# Altium schematic sheet Sensors.SchDoc(Sensors)
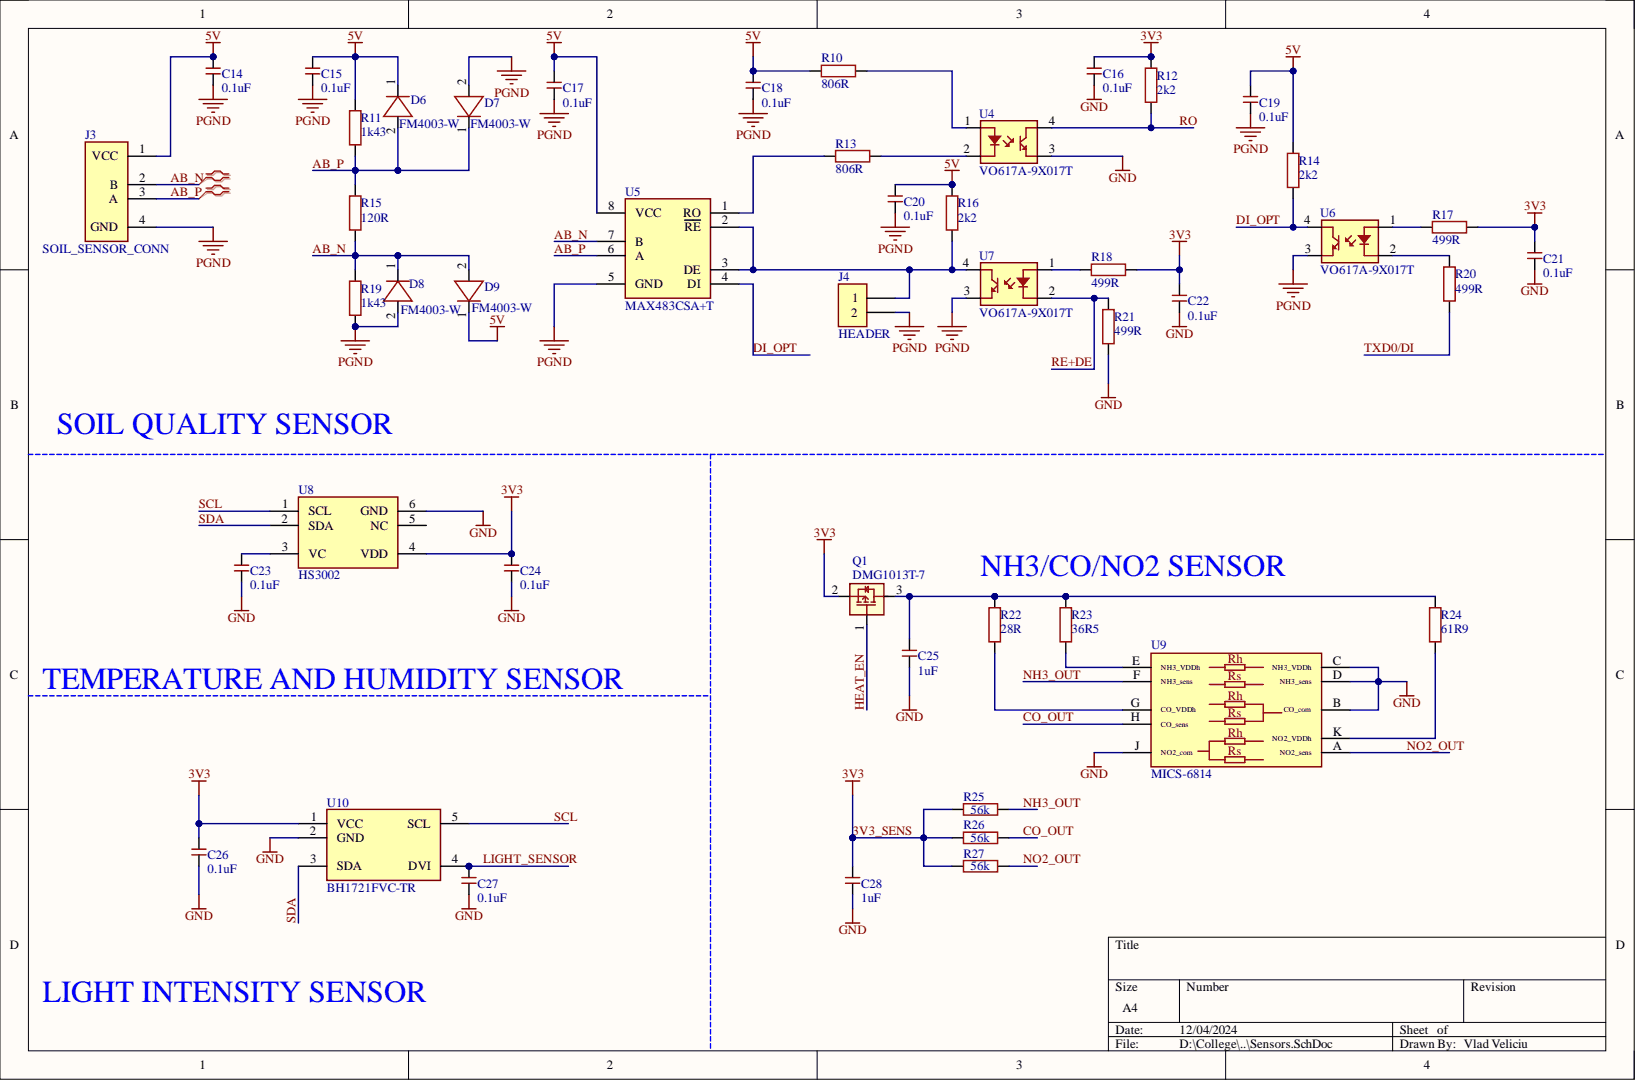
[diagram p0: Sensors.SchDoc]

## Parts
C14  0.1uF  [C0603]
C15  0.1uF  [C0603]
C16  0.1uF  [C0603]
C17  0.1uF  [C0603]
C18  0.1uF  [C0603]
C19  0.1uF  [C0603]
C20  0.1uF  [C0603]
C21  0.1uF  [C0603]
C22  0.1uF  [C0603]
C23  0.1uF  [C0603]
C24  0.1uF  [C0603]
C25  1uF  [C0805]
C26  0.1uF  [C0603]
C27  0.1uF  [C0603]
C28  1uF  [C0805]
D6  FM4003-W  [FM4003]
D7  FM4003-W  [FM4003]
D8  FM4003-W  [FM4003]
D9  FM4003-W  [FM4003]
J3  SOIL_SENSOR_CONN  [9993920000]
J4  HEADER  [TMM-104-YY-XX-D-SM-2pins]
Q1  DMG1013T-7  [SOT523]
R10  806R  [R0805]
R11  1k43  [R1206]
R12  2k2  [R0805]
R13  806R  [R0805]
R14  2k2  [R0805]
R15  120R  [R0805]
R16  2k2  [R0805]
R17  499R  [R0805]
R18  499R  [R0805]
R19  1k43  [R1206]
R20  499R  [R0805]
R21  499R  [R0805]
R22  28R  [R0603]
R23  36R5  [R0805]
R24  61R9  [R0805]
R25  56k  [R0805]
R26  56k  [R0805]
R27  56k  [R0805]
U4  VO617A-9X017T  [VO617A-9X017T]
U5  MAX483CSA+T  [SOIC-8]
U6  VO617A-9X017T  [VO617A-9X017T]
U7  VO617A-9X017T  [VO617A-9X017T]
U8  HS3002  [6-LGA]
U9  MICS-6814  [MICS-6814]
U10  BH1721FVC-TR  [WSOF5]

## Nets
5V: C14.2 C15.2 C17.2 C18.2 C19.2 C20.2 D6.1 D9.1 J3.1(VCC) R10.1 R11.2 R14.2 R16.2 U5.8(VCC)
GND: C16.1 C21.1 C22.1 C23.1 C24.1 C25.1 C26.1 C27.1 C28.1 R21.1 U4.3 U8.6(GND) U9.B(CO_com) U9.C(NH3_VDDh) U9.D(NH3_sens) U9.J(NO2_com) U10.2(GND)
PGND: C14.1 C15.1 C17.1 C18.1 C19.1 C20.1 D7.2 D8.2 J3.4(GND) J4.2 R19.1 U5.5(GND) U6.3 U7.3
3V3_SENS: C16.2 C21.2 C22.2 C24.2 C26.2 C28.2 Q1.2 R12.2 R17.2 R18.2 R25.1 R26.1 R27.1 U8.4(VDD) U10.1(VCC)
AB_N: D8.1 D9.2 J3.2(B) R15.1 R19.2 U5.7(B)
AB_P: D6.2 D7.1 J3.3(A) R11.1 R15.2 U5.6(A)
CO_OUT: R26.2 U9.H(CO_sens)
DI_OPT: R14.1 U5.4(DI) U6.4
HEAT_EN: Q1.1
LIGHT_SENSOR: C27.2 U10.4(DVI)
NH3_OUT: R25.2 U9.F(NH3_sens)
NO2_OUT: R27.2 U9.A(NO2_sens)
RE+DE: R21.2 U7.2
RO: R12.1 U4.4
SCL: U8.1(SCL) U10.5(SCL)
SDA: U8.2(SDA) U10.3(SDA)
TXD0/DI: R20.1
NetC23_2: C23.2 U8.3(VC)
NetC25_2: C25.2 Q1.3 R22.2 R23.2 R24.2
NetJ4_1: J4.1 R16.1 U5.2(RE) U5.3(DE) U7.4
NetR10_2: R10.2 U4.1
NetR13_1: R13.1 U5.1(RO)
NetR13_2: R13.2 U4.2
NetR17_1: R17.1 U6.1
NetR18_1: R18.1 U7.1
NetR20_2: R20.2 U6.2
NetR22_1: R22.1 U9.G(CO_VDDh)
NetR23_1: R23.1 U9.E(NH3_VDDh)
NetR24_1: R24.1 U9.K(NO2_VDDh)

Not connected: U8 5(NC)

## Library data of the parts
C14-C24 C26 C27 = C0603C104K8RAC: Ceramic Capacitor, Multilayer, Ceramic, 10V, 10% +Tol, 10% -Tol, X7R, 15% TC, 0.1uF, Surface Mount, 0603; Manufacturer: KEMET; Multilayer: Yes; Value: 100nF; Capacitor Type: Ceramic; Case/Package: 0603; Category: Ceramic Capacitors; Component Kind: Standard; ComponentLink1Description: https://datasheet.ciiva.com:443/pdfs/VipMasterIC/IC/KEME/KEME-S-A0008991654/KEME-S-A0008991654-1.pdf?src-supplier=IHS+Markit; ComponentLink1URL: https://datasheet.ciiva.com:443/pdfs/VipMasterIC/IC/KEME/KEME-S-A0008991654/KEME-S-A0008991654-1.pdf?src-supplier=IHS+Markit; ComponentLink2Description: http://www.mouser.com/ds/2/212/KEM_C1002_X7R_SMD-1102033.pdf?src-supplier=Mouser; ComponentLink2URL: http://www.mouser.com/ds/2/212/KEM_C1002_X7R_SMD-1102033.pdf?src-supplier=Mouser; Dielectric Material: Ceramic; Height: 0.8mm; JESD-609 Code: e3; Length: 1.6mm; Library Name: Schlib1.SchLib; Manufacturer Part Number: C0603C104K8RAC; Max Operating Temperature: 125°C; Min Operating Temperature: -55°C; Mounting Technology: Surface Mount; Package Shape: Rectangular; Package Style: SMTMeter; Packaging: Tape and Reel; Pins: 2; Pricing: 1=0.1, 10=0.061, 50=0.061, 100=0.031, 1000=0.022, 10000=0.015 (USD); Rated DC Voltage (URdc): 10V; Reach SVHC Compliant: Yes; RoHS: Yes; RoHS Compliant: Yes; Stock: 209299; Supplier: Mouser; Supplier Part Number: 80-C0603C104K8RAC; Temperature Characteristics Code: X7R; Terminal Finish: Nickel; Termination: Wraparound; Tolerance  (fill in as +-): 10%; Tolerance (fill in as +-): 10%; Width: 0.8mm
C25 C28 = C0805C105J4RACTU: Ceramic Capacitor, Multilayer, Ceramic, 16V, 5% +Tol, 5% -Tol, X7R, 15% TC, 1uF, Surface Mount, 0805; Manufacturer: KEMET; Multilayer: Yes; Capacitor Type: Ceramic; Case/Package: 0805; Category: Ceramic Capacitors; Component Kind: Standard; ComponentLink1Description: https://datasheet.ciiva.com:443/pdfs/VipMasterIC/IC/KEME/KEME-S-A0008991654/KEME-S-A0008991654-1.pdf?src-supplier=IHS+Markit; ComponentLink1URL: https://datasheet.ciiva.com:443/pdfs/VipMasterIC/IC/KEME/KEME-S-A0008991654/KEME-S-A0008991654-1.pdf?src-supplier=IHS+Markit; ComponentLink2Description: http://www.farnell.com/datasheets/2315440.pdf?src-supplier=Farnell; ComponentLink2URL: http://www.farnell.com/datasheets/2315440.pdf?src-supplier=Farnell; ComponentLink3Description: http://components.arrow.com/part/dataview/30748390S8976888N7429?src-supplier=Arrow+Electronics; ComponentLink3URL: http://components.arrow.com/part/dataview/30748390S8976888N7429?src-supplier=Arrow+Electronics; ComponentLink4Description: http://www.farnell.com/datasheets/2746093.pdf?src-supplier=Farnell; ComponentLink4URL: http://www.farnell.com/datasheets/2746093.pdf?src-supplier=Farnell; ComponentLink5Description: http://www.mouser.com/ds/2/212/KEM_C1002_X7R_SMD-1102033.pdf?src-supplier=Mouser; ComponentLink5URL: http://www.mouser.com/ds/2/212/KEM_C1002_X7R_SMD-1102033.pdf?src-supplier=Mouser; ComponentLink6Description: http://datasheet.octopart.com/C0805C105J4RACTU-KEMET-datasheet-101576154.pdf?src-supplier=Rs; ComponentLink6URL: http://datasheet.octopart.com/C0805C105J4RACTU-KEMET-datasheet-101576154.pdf?src-supplier=Rs; ComponentLink7Description: http://capacitoredge.kemet.com/capedge2/DataSheet?pn=C0805C105J4RACTU&src-supplier=Avnet; ComponentLink7URL: http://capacitoredge.kemet.com/capedge2/DataSheet?pn=C0805C105J4RACTU&src-supplier=Avnet; ComponentLink8Description: https://datasheet.octopart.com/C0805C105J4RACTU-Kemet-datasheet-21527579.pdf?src-supplier=Newark; ComponentLink8URL: https://datasheet.octopart.com/C0805C105J4RACTU-Kemet-datasheet-21527579.pdf?src-supplier=Newark; ComponentLink9Description: http://www.farnell.com/datasheets/2746093.pdf?src-supplier=Newark; ComponentLink9URL: http://www.farnell.com/datasheets/2746093.pdf?src-supplier=Newark; ComponentLink10Description: http://datasheet.octopart.com/C0805C105J4RACTU-KEMET-datasheet-101576154.pdf?src-supplier=Digikey; ComponentLink10URL: http://datasheet.octopart.com/C0805C105J4RACTU-KEMET-datasheet-101576154.pdf?src-supplier=Digikey; ComponentLink11Description: https://api.kemet.com/component-edge/download/datasheet/C0805C105J4RACTU.pdf?src-supplier=Digikey; ComponentLink11URL: https://api.kemet.com/component-edge/download/datasheet/C0805C105J4RACTU.pdf?src-supplier=Digikey; ComponentLink12Description: http://datasheet.octopart.com/C0805C105J4RACTU-KEMET-datasheet-101576154.pdf?src-supplier=Onlinecomponents.com; ComponentLink12URL: http://datasheet.octopart.com/C0805C105J4RACTU-KEMET-datasheet-101576154.pdf?src-supplier=Onlinecomponents.com; ComponentLink13Description: http://www.farnell.com/datasheets/2746093.pdf?src-supplier=Element14; ComponentLink13URL: http://www.farnell.com/datasheets/2746093.pdf?src-supplier=Element14; ComponentLink14Description: https://datasheet.octopart.com/C0805C105J4RACTU-Kemet-datasheet-65850099.pdf?src-supplier=Element14; ComponentLink14URL: https://datasheet.octopart.com/C0805C105J4RACTU-Kemet-datasheet-65850099.pdf?src-supplier=Element14; ComponentLink15Description: http://www.farnell.com/datasheets/1828800.pdf?src-supplier=Element14; ComponentLink15URL: http://www.farnell.com/datasheets/1828800.pdf?src-supplier=Element14; ComponentLink16Description: https://datasheet.octopart.com/C0805C105J4RACTU-KEMET-datasheet-37550250.pdf?src-supplier=Electro+Sonic; ComponentLink16URL: https://datasheet.octopart.com/C0805C105J4RACTU-KEMET-datasheet-37550250.pdf?src-supplier=Electro+Sonic; Dielectric Material: Ceramic; Height: 0.9mm; JESD-609 Code: e3; Length: 2mm; Library Name: Schlib1.SchLib; Manufacturer Part Number: C0805C105J4RACTU; Max Operating Temperature: 125°C; Min Operating Temperature: -55°C; Mounting Technology: Surface Mount; Package Shape: Rectangular; Package Style: SMTMeter; Packaging: Tape and Reel; Pins: 2; Pricing: 1=0.32, 10=0.209, 50=0.1542, 100=0.1255, 500=0.09908, 1000=0.08367 (USD); Rated DC Voltage (URdc): 16V; Reach SVHC Compliant: Yes; RoHS: Yes; RoHS Compliant: Yes; Stock: 23162; Supplier: Digikey; Supplier Part Number: 399-C0805C105J4RAC7800CT-ND; Temperature Characteristics Code: X7R; Terminal Finish: Nickel; Termination: Wraparound; Tolerance  (fill in as +-): 5%; Tolerance (fill in as +-): 5%; Value: 1uF; Width: 1.25mm
D6-D9 = FM4003-W: Rectifier Diode, 1 Phase, 1 Element, 1A, 200V V(RRM), Silicon, DO-214AC; Manufacturer: Rectron; Forward Voltage: 1.1V; Non-rep Pk Forward Current-Max: 30A; Number of Elements: 1; Package Body Material: Plastic; Qualification Status: Not Qualified; Time @ Peak Reflow Temperature-Max (s): 10s; Category: Rectifier Diodes; Component Kind: Standard; ComponentLink1Description: https://datasheet.ciiva.com:443/pdfs/VipMasterIC/IC/RECT/RECT-S-A0006483850/RECT-S-A0006483850-1.pdf?src-supplier=IHS+Markit; ComponentLink1URL: https://datasheet.ciiva.com:443/pdfs/VipMasterIC/IC/RECT/RECT-S-A0006483850/RECT-S-A0006483850-1.pdf?src-supplier=IHS+Markit; ComponentLink2Description: http://www.mouser.com/ds/2/345/rectron_fm4001w-fm4007w-347498.pdf?src-supplier=Mouser; ComponentLink2URL: http://www.mouser.com/ds/2/345/rectron_fm4001w-fm4007w-347498.pdf?src-supplier=Mouser; Diode Element Material: SILICON; Diode Type: RECTIFIER; JEDEC-95 Code: DO-214AC; JESD-30 Code: R-PDSO-C2; JESD-609 Code: e3; Library Name: Schlib1.SchLib; Manufacturer Part Number: FM4003-W; Max Operating Temperature: 150°C; Max Output current: 1A; Package Shape: Rectangular; Package Style: SMALL OUTLINEMeter; Peak Reflow Temperature (Cel): 260°C; Pins: 2; Pricing: 1=0.24, 10=0.16, 50=0.16, 100=0.089, 1000=0.052, 10000=0.034 (USD); Reach SVHC Compliant: No; Rep Pk Reverse Voltage-Max: 200V; RoHS: Yes; RoHS Compliant: Yes; Stock: 13549; Supplier: Mouser; Supplier Part Number: 583-FM4003-W; Surface Mount: Yes; Terminal Finish: Tin; Terminal Form: C BEND; Terminal Position: DUAL
J3 = 9993920000: Barrier Strip Terminal Block, 17.5A, 2.5mm2, 1 Row(s), 1 Deck(s); Manufacturer: Weidmuller; Additional Feature: STANDARD: UL 94V-0; Fastening Method: SCREW; Number of Decks: 1; Number of Ways: 4; Safety Approval: UL,CSA; Voltage Rating: 630V; Wire/Cable Gauge: 14AWG; Category: Terminal Blocks; Component Kind: Standard; ComponentLink1Description: https://datasheet.ciiva.com:443/pdfs/VipMasterIC/IC/WEID/WEIDS20112/WEIDS20112-1.pdf?src-supplier=IHS+Markit; ComponentLink1URL: https://datasheet.ciiva.com:443/pdfs/VipMasterIC/IC/WEID/WEIDS20112/WEIDS20112-1.pdf?src-supplier=IHS+Markit; ComponentLink2Description: https://datasheet.ciiva.com/29680/printed20circuit20board20terminals-29680544.pdf?src-supplier=Digikey; ComponentLink2URL: https://datasheet.ciiva.com/29680/printed20circuit20board20terminals-29680544.pdf?src-supplier=Digikey; Current Rating: 17.5A; Library Name: Schlib1.SchLib; Manufacturer Part Number: 9993920000; Mounting Technology: Board; Number of Rows: 1; Pricing: 1=1.76, 10=1.235, 25=1.152, 50=1.0454, 100=1.0408, 250=0.87892, 500=0.83264, 1000=0.74013, 5000=0.60135 (USD); Reach SVHC Compliant: Yes; RoHS: Yes; RoHS Compliant: Yes; Stock: 1634; Supplier: Digikey; Supplier Part Number: 281-1437-ND; Terminal and Terminal Block Type: BARRIER STRIP TERMINAL BLOCK; Wire Cross Section: 2.5mm2
J4 = TMM-104-06-F-D-SM_2pins: Board Connector, 8 Contact(s), 2 Row(s), Male, Straight, 0.079 inch Pitch, Surface Mount Terminal, Black Insulator; Manufacturer: Samtec; Manufacturer Series: TMM-1; Body Breadth: 0.155inch; Body Depth: 0.109inch; Body Length: 0.316inch; Contact Pattern: RECTANGULAR; Contact Style: SQ PIN-SKT; DIN Conformance: No; Mounting Option 1: LOCKING; Number Of Connectors: 1; Number of Contacts: 8; Number Of PCB Rows: 2; Option: General Purpose; Plating Thickness: 3uin; Reference Standard: UL; Reliability: COMMERCIAL; Category: Headers and Wire Housings; Component Kind: Standard; ComponentLink1Description: https://datasheet.ciiva.com:443/pdfs/VipMasterIC/IC/SAMI/SAMI-S-A0001541321/SAMI-S-A0001541321-1.pdf?src-supplier=IHS+Markit; ComponentLink1URL: https://datasheet.ciiva.com:443/pdfs/VipMasterIC/IC/SAMI/SAMI-S-A0001541321/SAMI-S-A0001541321-1.pdf?src-supplier=IHS+Markit; ComponentLink2Description: https://datasheet.ciiva.com/10163/tmm-sm-10163085.pdf?src-supplier=Avnet; ComponentLink2URL: https://datasheet.ciiva.com/10163/tmm-sm-10163085.pdf?src-supplier=Avnet; Connector Type: BOARD CONNECTOR; Contact Finish - Mating: GOLD FLASH (3) OVER NICKEL (50); Contact Finish - Termination: MATTE TIN; Contact Gender: Male; Contact Material: Bronze; Filter Feature: No; Flammability Rating: UL94 V-0; IEC Conformance: No; Insulation Material: Polymer; JESD-609 Code: e3; Library Name: Schlib1.SchLib; Manufacturer Part Number: TMM-104-06-F-D-SM; Mating Contact Pitch: 0.079inch; Mating Contact Row Spacing: 0.079inch; MIL Conformance: No; Mixed Contacts: No; Mounting Style: STRAIGHT; Mounting Technology: Board; Number of Rows Loaded: 2; PCB Contact Pattern: RECTANGULAR; Pricing: 1=1.64, 10=1.424, 100=1.2726, 500=1.0605, 1000=0.909, 5000=0.80598, 10000=0.7878 (USD); Reach SVHC Compliant: Yes; RoHS: Yes; RoHS Compliant: Yes; Stock: 201; Supplier: Digikey; Supplier Part Number: TMM-104-06-F-D-SM-ND; Terminal Pitch: 2mm; Termination: SMD/SMT
Q1 = DMG1013T-7: Small Signal Field-Effect Transistor, 0.46A I(D), 20V, 1-Element, P-Channel, Silicon, Metal-oxide Semiconductor FET; Manufacturer: Diodes Zetex; Additional Feature: HIGH RELIABILITY; Drain Current-Max (Abs) (ID): 460mA; Drain-source On Resistance-Max: 700mR; Number of Elements: 1; Operating Mode: ENHANCEMENT MODE; Package Body Material: Plastic; Power Dissipation-Max (Abs): 270mW; Qualification Status: Not Qualified; Time @ Peak Reflow Temperature-Max (s): 40s; Transistor Application: SWITCHING; Category: MOSFETs; Component Kind: Standard; ComponentLink1Description: https://datasheet.ciiva.com:443/pdfs/VipMasterIC/IC/DIOD/DIODS21799/DIODS21799-1.pdf?src-supplier=IHS+Markit; ComponentLink1URL: https://datasheet.ciiva.com:443/pdfs/VipMasterIC/IC/DIOD/DIODS21799/DIODS21799-1.pdf?src-supplier=IHS+Markit; ComponentLink2Description: https://datasheet.ciiva.com/8115/ds31784-8115334.pdf?src-supplier=Avnet; ComponentLink2URL: https://datasheet.ciiva.com/8115/ds31784-8115334.pdf?src-supplier=Avnet; ComponentLink3Description: https://datasheet.ciiva.com/4442/ds31784-89155-4442973.pdf?src-supplier=Mouser; ComponentLink3URL: https://datasheet.ciiva.com/4442/ds31784-89155-4442973.pdf?src-supplier=Mouser; ComponentLink4Description: https://datasheet.ciiva.com/6383/ds31784-6383793.pdf?src-supplier=Digikey; ComponentLink4URL: https://datasheet.ciiva.com/6383/ds31784-6383793.pdf?src-supplier=Digikey; Drain Current-Max (ID): 460mA; DS Breakdown Voltage-Min: 20V; FET Technology: METAL-OXIDE SEMICONDUCTOR; JESD-30 Code: R-PDSO-G3; JESD-609 Code: e3; Library Name: Schlib1.SchLib; Manufacturer Part Number: DMG1013T-7; Max Operating Temperature: 150°C; Moisture Sensitivity Level: 1; Package Shape: Rectangular; Package Style: SMALL OUTLINEMeter; Peak Reflow Temperature (Cel): 260°C; Pins: 3; Polarity/Channel Type: P-CHANNEL; Pricing: 1=0.31, 10=0.21, 100=0.1027, 500=0.08562, 1000=0.05949 (USD); Reach SVHC Compliant: Yes; RoHS: Yes; RoHS Compliant: Yes; Stock: 242786; Supplier: Digikey; Supplier Part Number: DMG1013T-7DICT-ND; Surface Mount: Yes; Terminal Finish: Tin; Terminal Form: Gull Wing; Terminal Position: DUAL; Transistor Element Material: SILICON
R10 R13 = RT0805BRD07806RL: Fixed Resistor, Thin Film, 0.125W, 806ohm, 150V, 0.1% +/-Tol, 25ppm/Cel, Surface Mount, 0805; Manufacturer: Yageo; Additional Feature: HIGH PRECISION; Package Height: 0.5mm; Package Length: 2mm; Package Width: 1.25mm; Power: 125mW; Rated Temperature: 70°C; Temperature Coefficient: 25ppm/°C; Case/Package: 0805; Category: Chip SMD Resistors; Component Kind: Standard; ComponentLink1Description: https://datasheet.ciiva.com:443/pdfs/VipMasterIC/IC/YAGO/YAGO-S-A0008510989/YAGO-S-A0008510989-1.pdf?src-supplier=IHS+Markit; ComponentLink1URL: https://datasheet.ciiva.com:443/pdfs/VipMasterIC/IC/YAGO/YAGO-S-A0008510989/YAGO-S-A0008510989-1.pdf?src-supplier=IHS+Markit; ComponentLink2Description: https://datasheet.ciiva.com/2585/pyu-rt-1-to-0-05-rohs-l-5-2585181.pdf?src-supplier=Digikey; ComponentLink2URL: https://datasheet.ciiva.com/2585/pyu-rt-1-to-0-05-rohs-l-5-2585181.pdf?src-supplier=Digikey; Construction: Chip; Library Name: Schlib1.SchLib; Manufacturer Part Number: RT0805BRD07806RL; Max Operating Temperature: 155°C; Min Operating Temperature: -55°C; Mounting Technology: Surface Mount; Package Style: SMTMeter; Packaging: Tape and Reel; Pins: 2; Pricing: 1=0.16, 10=0.12, 50=0.095, 100=0.085, 1000=0.057, 10000=0.038 (USD); Reach SVHC Compliant: Yes; Resistor Type: FIXED RESISTOR; RoHS: Yes; RoHS Compliant: Yes; Stock: 2476; Supplier: Mouser; Supplier Part Number: 603-RT0805BRD07806RL; Technology: THIN FILM; Termination: Wraparound; Tolerance: 0.1%; Value: 806R; Working Voltage: 150V
R11 R19 = RT1206FRE071K43L: Fixed Resistor, Thin Film, 0.25W, 1430ohm, 200V, 1% +/-Tol, 50ppm/Cel, Surface Mount, 1206; Manufacturer: Yageo; Additional Feature: HIGH PRECISION; Package Height: 0.55mm; Package Length: 3.1mm; Package Width: 1.6mm; Power: 250mW; Rated Temperature: 70°C; Temperature Coefficient: 50ppm/°C; Value: 1.43kR; Case/Package: 1206; Category: Chip SMD Resistors; Component Kind: Standard; ComponentLink1Description: https://datasheet.ciiva.com:443/pdfs/VipMasterIC/IC/YAGO/YAGO-S-A0008510989/YAGO-S-A0008510989-1.pdf?src-supplier=IHS+Markit; ComponentLink1URL: https://datasheet.ciiva.com:443/pdfs/VipMasterIC/IC/YAGO/YAGO-S-A0008510989/YAGO-S-A0008510989-1.pdf?src-supplier=IHS+Markit; ComponentLink2Description: https://datasheet.ciiva.com/2566/pyu-rt-1-to-0-05-rohs-l-5-2566581.pdf?src-supplier=Digikey; ComponentLink2URL: https://datasheet.ciiva.com/2566/pyu-rt-1-to-0-05-rohs-l-5-2566581.pdf?src-supplier=Digikey; ComponentLink3Description: https://datasheet.ciiva.com/12703/pyu-rt-1-to-0-05-rohs-l-4-12703135.pdf?src-supplier=Mouser; ComponentLink3URL: https://datasheet.ciiva.com/12703/pyu-rt-1-to-0-05-rohs-l-4-12703135.pdf?src-supplier=Mouser; Construction: Chip; Library Name: Schlib1.SchLib; Manufacturer Part Number: RT1206FRE071K43L; Max Operating Temperature: 155°C; Min Operating Temperature: -55°C; Mounting Technology: Surface Mount; Package Style: SMTMeter; Packaging: Tape and Reel; Pins: 2; Pricing: 1=0.1, 10=0.037, 50=0.037, 100=0.034, 1000=0.023, 10000=0.015 (USD); Reach SVHC Compliant: Yes; Resistor Type: FIXED RESISTOR; RoHS: Yes; RoHS Compliant: Yes; Stock: 7405; Supplier: Mouser; Supplier Part Number: 603-RT1206FRE071K43L; Technology: THIN FILM; Termination: Wraparound; Tolerance: 1%; Working Voltage: 200V
R12 R14 R16 = RC0805DR-072K2L: Fixed Resistor, Metal Glaze/thick Film, 0.125W, 2200ohm, 150V, 0.5% +/-Tol, 100ppm/Cel, Surface Mount, 0805; Manufacturer: Yageo; Additional Feature: LASER TRIMMABLE; Package Height: 0.5mm; Package Length: 2mm; Package Width: 1.25mm; Power: 125mW; Rated Temperature: 70°C; Temperature Coefficient: 100ppm/°C; Value: 2.2kR; Case/Package: 0805; Category: Chip SMD Resistors; Component Kind: Standard; ComponentLink1Description: https://datasheet.ciiva.com:443/pdfs/VipMasterIC/IC/YAGO/YAGO-S-A0008145938/YAGO-S-A0008145938-1.pdf?src-supplier=IHS+Markit; ComponentLink1URL: https://datasheet.ciiva.com:443/pdfs/VipMasterIC/IC/YAGO/YAGO-S-A0008145938/YAGO-S-A0008145938-1.pdf?src-supplier=IHS+Markit; ComponentLink2Description: http://www.yageo.com.tw/exep/pages/download/literatures/PYu-R_INT-thick_7.pdf?src-supplier=Digikey; ComponentLink2URL: http://www.yageo.com.tw/exep/pages/download/literatures/PYu-R_INT-thick_7.pdf?src-supplier=Digikey; Construction: Chip; JESD-609 Code: e3; Library Name: Schlib1.SchLib; Manufacturer Part Number: RC0805DR-072K2L; Max Operating Temperature: 155°C; Min Operating Temperature: -55°C; Mounting Technology: Surface Mount; Package Shape: Rectangular; Package Style: SMTMeter; Packaging: Tape and Reel; Pins: 2; Pricing: 1=0.13, 10=0.063, 50=0.0414, 100=0.0352, 500=0.02476, 1000=0.02156 (USD); Reach SVHC Compliant: Yes; Resistor Type: FIXED RESISTOR; RoHS: Yes; RoHS Compliant: Yes; Stock: 19275; Supplier: Digikey; Supplier Part Number: 13-RC0805DR-072K2LCT-ND; Technology: METAL GLAZE/THICK FILM; Terminal Finish: Nickel; Termination: Wraparound; Tolerance: 0.5%; Working Voltage: 150V
R15 = RT0805DRD07120RL: Fixed Resistor, Thin Film, 0.125W, 120ohm, 150V, 0.5% +/-Tol, 25ppm/Cel, Surface Mount, 0805; Manufacturer: Yageo; Additional Feature: HIGH PRECISION; Package Height: 0.5mm; Package Length: 2mm; Package Width: 1.25mm; Power: 125mW; Rated Temperature: 70°C; Temperature Coefficient: 25ppm/°C; Case/Package: 0805; Category: Chip SMD Resistors; Component Kind: Standard; ComponentLink1Description: https://datasheet.ciiva.com:443/pdfs/VipMasterIC/IC/YAGO/YAGO-S-A0008510989/YAGO-S-A0008510989-1.pdf?src-supplier=IHS+Markit; ComponentLink1URL: https://datasheet.ciiva.com:443/pdfs/VipMasterIC/IC/YAGO/YAGO-S-A0008510989/YAGO-S-A0008510989-1.pdf?src-supplier=IHS+Markit; ComponentLink2Description: http://www.yageo.com/documents/recent/PYu-RT_1-to-0.01_RoHS_L_9.pdf?src-supplier=Digikey; ComponentLink2URL: http://www.yageo.com/documents/recent/PYu-RT_1-to-0.01_RoHS_L_9.pdf?src-supplier=Digikey; Construction: Chip; Library Name: Schlib1.SchLib; Manufacturer Part Number: RT0805DRD07120RL; Max Operating Temperature: 155°C; Min Operating Temperature: -55°C; Mounting Technology: Surface Mount; Package Style: SMTMeter; Packaging: Tape and Reel; Pins: 2; Pricing: 1=0.1, 10=0.076, 50=0.0606, 100=0.0545, 500=0.0418, 1000=0.03701 (USD); Reach SVHC Compliant: Yes; Resistor Type: FIXED RESISTOR; RoHS: Yes; RoHS Compliant: Yes; Stock: 4920; Supplier: Digikey; Supplier Part Number: 13-RT0805DRD07120RLCT-ND; Technology: THIN FILM; Termination: Wraparound; Tolerance: 0.5%; Value: 120R; Working Voltage: 150V
R17 R18 R20 R21 = RT0805DRE07499RL: Fixed Resistor, Thin Film, 0.125W, 499ohm, 150V, 0.5% +/-Tol, 50ppm/Cel, Surface Mount, 0805; Manufacturer: Yageo; Additional Feature: HIGH PRECISION; Package Height: 0.5mm; Package Length: 2mm; Package Width: 1.25mm; Power: 125mW; Rated Temperature: 70°C; Temperature Coefficient: 50ppm/°C; Case/Package: 0805; Category: Chip SMD Resistors; Component Kind: Standard; ComponentLink1Description: https://datasheet.ciiva.com:443/pdfs/VipMasterIC/IC/YAGO/YAGO-S-A0008510989/YAGO-S-A0008510989-1.pdf?src-supplier=IHS+Markit; ComponentLink1URL: https://datasheet.ciiva.com:443/pdfs/VipMasterIC/IC/YAGO/YAGO-S-A0008510989/YAGO-S-A0008510989-1.pdf?src-supplier=IHS+Markit; ComponentLink2Description: https://datasheet.ciiva.com/2569/pyu-rt-1-to-0-05-rohs-l-5-2569517.pdf?src-supplier=Digikey; ComponentLink2URL: https://datasheet.ciiva.com/2569/pyu-rt-1-to-0-05-rohs-l-5-2569517.pdf?src-supplier=Digikey; Construction: Chip; Library Name: Schlib1.SchLib; Manufacturer Part Number: RT0805DRE07499RL; Max Operating Temperature: 155°C; Min Operating Temperature: -55°C; Mounting Technology: Surface Mount; Package Style: SMTMeter; Packaging: Tape and Reel; Pins: 2; Pricing: 1=0.1, 10=0.047, 50=0.0392, 100=0.0357, 500=0.02826, 1000=0.02534 (USD); Reach SVHC Compliant: Yes; Resistor Type: FIXED RESISTOR; RoHS: Yes; RoHS Compliant: Yes; Stock: 13062; Supplier: Digikey; Supplier Part Number: 311-2868-1-ND; Technology: THIN FILM; Termination: Wraparound; Tolerance: 0.5%; Value: 499R; Working Voltage: 150V
R22 = RT0603BRD0728RL: Fixed Resistor, Thin Film, 0.1W, 28ohm, 75V, 0.1% +/-Tol, 25ppm/Cel, Surface Mount, 0603; Manufacturer: Yageo; Package Height: 0.45mm; Package Length: 1.6mm; Package Width: 0.8mm; Power: 100mW; Rated Temperature: 70°C; Temperature Coefficient: 25ppm/°C; Case/Package: 0603; Category: Chip SMD Resistors; Component Kind: Standard; ComponentLink1Description: https://datasheet.ciiva.com:443/pdfs/VipMasterIC/IC/YAGO/YAGO-S-A0008510989/YAGO-S-A0008510989-1.pdf?src-supplier=IHS+Markit; ComponentLink1URL: https://datasheet.ciiva.com:443/pdfs/VipMasterIC/IC/YAGO/YAGO-S-A0008510989/YAGO-S-A0008510989-1.pdf?src-supplier=IHS+Markit; ComponentLink2Description: https://datasheet.ciiva.com/12703/pyu-rt-1-to-0-05-rohs-l-4-12703079.pdf?src-supplier=Mouser; ComponentLink2URL: https://datasheet.ciiva.com/12703/pyu-rt-1-to-0-05-rohs-l-4-12703079.pdf?src-supplier=Mouser; Construction: Chip; Library Name: Schlib1.SchLib; Manufacturer Part Number: RT0603BRD0728RL; Max Operating Temperature: 155°C; Min Operating Temperature: -55°C; Mounting Technology: Surface Mount; Package Style: SMTMeter; Packaging: Tape and Reel; Pins: 2; Pricing: 1=0.14, 10=0.104, 50=0.0822, 100=0.0737, 500=0.05612, 1000=0.04959 (USD); Reach SVHC Compliant: Yes; Resistor Type: FIXED RESISTOR; RoHS: Yes; RoHS Compliant: Yes; Stock: 5000; Supplier: Digikey; Supplier Part Number: 13-RT0603BRD0728RLCT-ND; Technology: THIN FILM; Termination: Wraparound; Tolerance: 0.1%; Value: 28R; Working Voltage: 75V
R23 = RT0805BRD0736R5L: Fixed Resistor, Thin Film, 0.125W, 36.5ohm, 150V, 0.1% +/-Tol, 25ppm/Cel, Surface Mount, 0805; Manufacturer: Yageo; Additional Feature: HIGH PRECISION; Package Height: 0.5mm; Package Length: 2mm; Package Width: 1.25mm; Power: 125mW; Rated Temperature: 70°C; Temperature Coefficient: 25ppm/°C; Value: 36.5R; Case/Package: 0805; Category: Chip SMD Resistors; Component Kind: Standard; ComponentLink1Description: https://datasheet.ciiva.com:443/pdfs/VipMasterIC/IC/YAGO/YAGO-S-A0008510989/YAGO-S-A0008510989-1.pdf?src-supplier=IHS+Markit; ComponentLink1URL: https://datasheet.ciiva.com:443/pdfs/VipMasterIC/IC/YAGO/YAGO-S-A0008510989/YAGO-S-A0008510989-1.pdf?src-supplier=IHS+Markit; ComponentLink2Description: https://datasheet.ciiva.com/12811/pyu-rt-1-to-0-05-rohs-l-4-12811269.pdf?src-supplier=Mouser; ComponentLink2URL: https://datasheet.ciiva.com/12811/pyu-rt-1-to-0-05-rohs-l-4-12811269.pdf?src-supplier=Mouser; Construction: Chip; Library Name: Schlib1.SchLib; Manufacturer Part Number: RT0805BRD0736R5L; Max Operating Temperature: 155°C; Min Operating Temperature: -55°C; Mounting Technology: Surface Mount; Package Style: SMTMeter; Packaging: Tape and Reel; Pins: 2; Pricing: 1=0.16, 10=0.12, 50=0.0946, 100=0.0846, 500=0.06418, 1000=0.05663 (USD); Reach SVHC Compliant: Yes; Resistor Type: FIXED RESISTOR; RoHS: Yes; RoHS Compliant: Yes; Stock: 5000; Supplier: Digikey; Supplier Part Number: 13-RT0805BRD0736R5LCT-ND; Technology: THIN FILM; Termination: Wraparound; Tolerance: 0.1%; Working Voltage: 150V
R24 = RT0805BRD0761R9L: Fixed Resistor, Thin Film, 0.125W, 61.9ohm, 150V, 0.1% +/-Tol, 25ppm/Cel, Surface Mount, 0805; Manufacturer: Yageo; Additional Feature: HIGH PRECISION; Package Height: 0.5mm; Package Length: 2mm; Package Width: 1.25mm; Power: 125mW; Rated Temperature: 70°C; Temperature Coefficient: 25ppm/°C; Value: 61.9R; Case/Package: 0805; Category: Chip SMD Resistors; Component Kind: Standard; ComponentLink1Description: https://datasheet.ciiva.com:443/pdfs/VipMasterIC/IC/YAGO/YAGO-S-A0008510989/YAGO-S-A0008510989-1.pdf?src-supplier=IHS+Markit; ComponentLink1URL: https://datasheet.ciiva.com:443/pdfs/VipMasterIC/IC/YAGO/YAGO-S-A0008510989/YAGO-S-A0008510989-1.pdf?src-supplier=IHS+Markit; ComponentLink2Description: https://datasheet.ciiva.com/2585/pyu-rt-1-to-0-05-rohs-l-4-2585122.pdf?src-supplier=Digikey; ComponentLink2URL: https://datasheet.ciiva.com/2585/pyu-rt-1-to-0-05-rohs-l-4-2585122.pdf?src-supplier=Digikey; ComponentLink3Description: https://datasheet.ciiva.com/46635/pyu-rt-1-to-0-05-rohs-l-5-46635326.pdf?src-supplier=Digikey; ComponentLink3URL: https://datasheet.ciiva.com/46635/pyu-rt-1-to-0-05-rohs-l-5-46635326.pdf?src-supplier=Digikey; Construction: Chip; Library Name: Schlib1.SchLib; Manufacturer Part Number: RT0805BRD0761R9L; Max Operating Temperature: 155°C; Min Operating Temperature: -55°C; Mounting Technology: Surface Mount; Package Style: SMTMeter; Packaging: Tape and Reel; Pins: 2; Pricing: 1=0.17, 10=0.121, 50=0.095, 100=0.085, 1000=0.057, 10000=0.038 (USD); Reach SVHC Compliant: Yes; Resistor Type: FIXED RESISTOR; RoHS: Yes; RoHS Compliant: Yes; Stock: 935; Supplier: Mouser; Supplier Part Number: 603-RT0805BRD0761R9L; Technology: THIN FILM; Termination: Wraparound; Tolerance: 0.1%; Working Voltage: 150V
R25-R27 = RT0805BRD0756KL: Fixed Resistor, Thin Film, 0.125W, 56000ohm, 150V, 0.1% +/-Tol, 25ppm/Cel, Surface Mount, 0805; Manufacturer: Yageo; Additional Feature: HIGH PRECISION; Package Height: 0.5mm; Package Length: 2mm; Package Width: 1.25mm; Power: 125mW; Rated Temperature: 70°C; Temperature Coefficient: 25ppm/°C; Value: 56kR; Case/Package: 0805; Category: Chip SMD Resistors; Component Kind: Standard; ComponentLink1Description: https://datasheet.ciiva.com:443/pdfs/VipMasterIC/IC/YAGO/YAGO-S-A0008510989/YAGO-S-A0008510989-1.pdf?src-supplier=IHS+Markit; ComponentLink1URL: https://datasheet.ciiva.com:443/pdfs/VipMasterIC/IC/YAGO/YAGO-S-A0008510989/YAGO-S-A0008510989-1.pdf?src-supplier=IHS+Markit; ComponentLink2Description: https://datasheet.ciiva.com/2585/pyu-rt-1-to-0-05-rohs-l-4-2585095.pdf?src-supplier=Digikey; ComponentLink2URL: https://datasheet.ciiva.com/2585/pyu-rt-1-to-0-05-rohs-l-4-2585095.pdf?src-supplier=Digikey; ComponentLink3Description: https://datasheet.ciiva.com/46634/pyu-rt-1-to-0-05-rohs-l-5-46634892.pdf?src-supplier=Digikey; ComponentLink3URL: https://datasheet.ciiva.com/46634/pyu-rt-1-to-0-05-rohs-l-5-46634892.pdf?src-supplier=Digikey; ComponentLink4Description: https://datasheet.ciiva.com/8888/pyu-rt-1-to-0-05-rohs-l-4-8888825.pdf?src-supplier=Avnet; ComponentLink4URL: https://datasheet.ciiva.com/8888/pyu-rt-1-to-0-05-rohs-l-4-8888825.pdf?src-supplier=Avnet; ComponentLink5Description: https://datasheet.ciiva.com/12810/pyu-rt-1-to-0-05-rohs-l-4-12810058.pdf?src-supplier=Mouser; ComponentLink5URL: https://datasheet.ciiva.com/12810/pyu-rt-1-to-0-05-rohs-l-4-12810058.pdf?src-supplier=Mouser; Construction: Chip; Library Name: Schlib1.SchLib; Manufacturer Part Number: RT0805BRD0756KL; Max Operating Temperature: 155°C; Min Operating Temperature: -55°C; Mounting Technology: Surface Mount; Package Style: SMTMeter; Packaging: Tape and Reel; Pins: 2; Pricing: 1=0.11, 10=0.082, 50=0.082, 100=0.06, 1000=0.041, 10000=0.026 (USD); Reach SVHC Compliant: Yes; Resistor Type: FIXED RESISTOR; RoHS: Yes; RoHS Compliant: Yes; Stock: 9249; Supplier: Mouser; Supplier Part Number: 603-RT0805BRD0756KL; Technology: THIN FILM; Termination: Wraparound; Tolerance: 0.1%; Working Voltage: 150V
U4 U6 U7 = VO617A-9X017T: Transistor Output Optocoupler, 1-Element, 5300V Isolation; Manufacturer: Vishay; Coll-emtr Bkdn Voltage-Min: 80V; Current Transfer Ratio-Nom: 200%; Dark Current-Max: 100nA; Forward Current: 60mA; Isolation Voltage-Max: 5.3kV; Number of Elements: 1; Category: Optocouplers; Component Kind: Standard; ComponentLink1Description: https://datasheet.ciiva.com:443/pdfs/VipMasterIC/IC/VISH/VISH-S-A0004680336/VISH-S-A0004680336-1.pdf?src-supplier=IHS+Markit; ComponentLink1URL: https://datasheet.ciiva.com:443/pdfs/VipMasterIC/IC/VISH/VISH-S-A0004680336/VISH-S-A0004680336-1.pdf?src-supplier=IHS+Markit; JESD-609 Code: e3; Library Name: Schlib1.SchLib; Manufacturer Part Number: VO617A-9X017T; Max Operating Temperature: 110°C; Min Operating Temperature: -55°C; Optoelectronic Device Type: TRANSISTOR OUTPUT OPTOCOUPLER; Pricing: 1=0.43, 10=0.263, 100=0.151 (USD); RoHS: Yes; RoHS Compliant: Yes; Stock: 79924; Supplier: Digikey; Supplier Part Number: 751-VO617A-9X017TCT-ND; Terminal Finish: Tin
U5 = MAX483CSA+T: Line Transceiver, 1 Func, 1 Driver, 1 Rcvr, CMOS, PDSO8; Manufacturer: Maxim; Channels: 1; Differential Output: Yes; High Level Input Current-Max: 1mA; Input Characteristics: DIFFERENTIAL SCHMITT TRIGGER; Max Supply Current: 650uA; Max Supply Voltage: 5.25V; Min Supply Voltage: 4.75V; Nominal Supply Voltage: 5V; Out Swing-Min: 1.5V; Output Characteristics: 3-STATE; Output Low Current-Max: 4mA; Output Polarity: Complementary; Package Body Material: Plastic; Package Equivalence Code: SOP8,.25; Qualification Status: Not Qualified; Receive Delay-Max: 2000ns; Case/Package: SOP; Category: Buffers, Drivers and Transceivers; Component Kind: Standard; ComponentLink1Description: https://datasheet.ciiva.com:443/pdfs/VipMasterIC/IC/MAXM/MAXMS13366/MAXMS13366-1.pdf?src-supplier=IHS+Markit; ComponentLink1URL: https://datasheet.ciiva.com:443/pdfs/VipMasterIC/IC/MAXM/MAXMS13366/MAXMS13366-1.pdf?src-supplier=IHS+Markit; ComponentLink2Description: https://datasheet.ciiva.com/22828/0900766b810e2699-22828273.pdf?src-supplier=Rs; ComponentLink2URL: https://datasheet.ciiva.com/22828/0900766b810e2699-22828273.pdf?src-supplier=Rs; ComponentLink3Description: https://datasheet.ciiva.com/14424/max1487-max491-14424942.pdf?src-supplier=Avnet; ComponentLink3URL: https://datasheet.ciiva.com/14424/max1487-max491-14424942.pdf?src-supplier=Avnet; ComponentLink4Description: https://datasheet.ciiva.com/12261/max1487-max491-96074-12261627.pdf?src-supplier=Mouser; ComponentLink4URL: https://datasheet.ciiva.com/12261/max1487-max491-96074-12261627.pdf?src-supplier=Mouser; Driver Number of Bits: 1; Height - Seated (Max): 1.75mm; Interface IC Type: LINE TRANSCEIVER; JESD-30 Code: R-PDSO-G8; JESD-609 Code: e3; Length: 4.9mm; Library Name: Schlib1.SchLib; Manufacturer Part Number: MAX483CSA+T; Max Operating Temperature: 70°C; Min Operating Temperature: 0°C; Moisture Sensitivity Level: 1; Package Shape: Rectangular; Package Style: SMALL OUTLINEMeter; Peak Reflow Temperature (Cel): 260°C; Pins: 8; Power Supplies: 5V; Pricing: 1=4.2, 10=3.774, 25=3.568, 100=3.0921, 250=2.93356, 500=2.63226, 1000=2.21998 (USD); Reach SVHC Compliant: Yes; Receiver Number of Bits: 1; RoHS: Yes; RoHS Compliant: Yes; Stock: 6824; Supplier: Digikey; Supplier Part Number: MAX483CSA+TCT-ND; Surface Mount: Yes; Technology: CMOS; Temperature Grade: COMMERCIAL; Terminal Finish: Tin; Terminal Form: Gull Wing; Terminal Pitch: 1.27mm; Terminal Position: DUAL; Transmit Delay-Max: 2000ns; Width: 3.9mm
U8 = HS3002: Temperature Sensor; Manufacturer: IDT; Sensor Type: TEMPERATURE SENSOR,SWITCH/DIGITAL OUTPUT,SERIAL; Category: Uncategorized; Component Kind: Standard; ComponentLink1Description: https://datasheet.ciiva.com:443/pdfs/VipMasterIC/IC/IDTI/IDTI-S-A0010065272/IDTI-S-A0010099625-1.pdf?src-supplier=IHS+Markit; ComponentLink1URL: https://datasheet.ciiva.com:443/pdfs/VipMasterIC/IC/IDTI/IDTI-S-A0010065272/IDTI-S-A0010099625-1.pdf?src-supplier=IHS+Markit; ComponentLink2Description: https://www.idt.com/document/dst/hs300x-datasheet?src-supplier=Digikey; ComponentLink2URL: https://www.idt.com/document/dst/hs300x-datasheet?src-supplier=Digikey; JESD-609 Code: e3; Library Name: Schlib1.SchLib; Manufacturer Part Number: HS3002; Reach SVHC Compliant: Yes; RoHS: Yes; RoHS Compliant: Yes; Supplier: Digikey; Supplier Part Number: 800-3831-ND; Terminal Finish: Tin
U9 = MICS-6814: CO/NO2/NH3 Triple Gas Sensor Metaloxide Gas Detection Sensor SMD T/R; Manufacturer: Amphenol; Category: Sensors; Component Kind: Standard; ComponentLink1Description: https://datasheet.octopart.com/MICS-6814-Amphenol-datasheet-134696678.pdf?src-supplier=TME; ComponentLink1URL: https://datasheet.octopart.com/MICS-6814-Amphenol-datasheet-134696678.pdf?src-supplier=TME; Library Name: Schlib1.SchLib; Manufacturer Part Number: MICS-6814; Pricing: 1=11.34, 10=9.08, 50=9.08, 100=7.19, 1000=6.29, 10000=6.29 (USD); RoHS: No; Stock: 1716; Supplier: Mouser; Supplier Part Number: 523-MICS-6814
U10 = BH1721FVC-TR: Analog Circuit, 1 Func, PDSO5; Manufacturer: Rohm; Channels: 1; Max Supply Voltage: 3.6V; Min Supply Voltage: 2.4V; Nominal Supply Voltage: 3V; Package Body Material: Plastic; Qualification Status: Not Qualified; Case/Package: VSON; Category: Optical Sensors; Component Kind: Standard; ComponentLink1Description: https://datasheet.ciiva.com:443/pdfs/VipMasterIC/IC/ROHM/ROHM-S-A0008990244/ROHM-S-A0008995748-1.pdf?src-supplier=IHS+Markit; ComponentLink1URL: https://datasheet.ciiva.com:443/pdfs/VipMasterIC/IC/ROHM/ROHM-S-A0008990244/ROHM-S-A0008995748-1.pdf?src-supplier=IHS+Markit; ComponentLink2Description: https://datasheet.ciiva.com/4697/bh1721fvc-e-210284-4697239.pdf?src-supplier=Mouser; ComponentLink2URL: https://datasheet.ciiva.com/4697/bh1721fvc-e-210284-4697239.pdf?src-supplier=Mouser; ComponentLink3Description: https://datasheet.ciiva.com/2819/bh1721fvc-e-2819305.pdf?src-supplier=Digikey; ComponentLink3URL: https://datasheet.ciiva.com/2819/bh1721fvc-e-2819305.pdf?src-supplier=Digikey; ComponentLink4Description: https://datasheet.ciiva.com/8113/bh1721fvc-e-8113662.pdf?src-supplier=Avnet; ComponentLink4URL: https://datasheet.ciiva.com/8113/bh1721fvc-e-8113662.pdf?src-supplier=Avnet; ComponentLink5Description: https://datasheet.ciiva.com/2819/bh1721fvc-e-2819307.pdf?src-supplier=Digikey; ComponentLink5URL: https://datasheet.ciiva.com/2819/bh1721fvc-e-2819307.pdf?src-supplier=Digikey; Height - Seated (Max): 0.6mm; Length: 1.6mm; Library Name: Schlib1.SchLib; Manufacturer Part Number: BH1721FVC-TR; Max Operating Temperature: 85°C; Min Operating Temperature: -40°C; Package Shape: Square; Package Style: SMALL OUTLINEMeter; Pins: 5; Pricing: 1=3.85, 10=2.891, 25=2.5696, 50=2.441, 100=2.3767, 500=1.99132, 1000=1.86284 (USD); Reach SVHC Compliant: Yes; RoHS: Yes; RoHS Compliant: Yes; Stock: 11114; Supplier: Digikey; Supplier Part Number: BH1721FVCCT-ND; Surface Mount: Yes; Temperature Grade: INDUSTRIAL; Terminal Form: No Lead; Terminal Pitch: 0.5mm; Terminal Position: DUAL; Throw Configuration: ANALOG CIRCUIT; Width: 1.6mm
